# Altium schematic sheet Drive.SchDoc
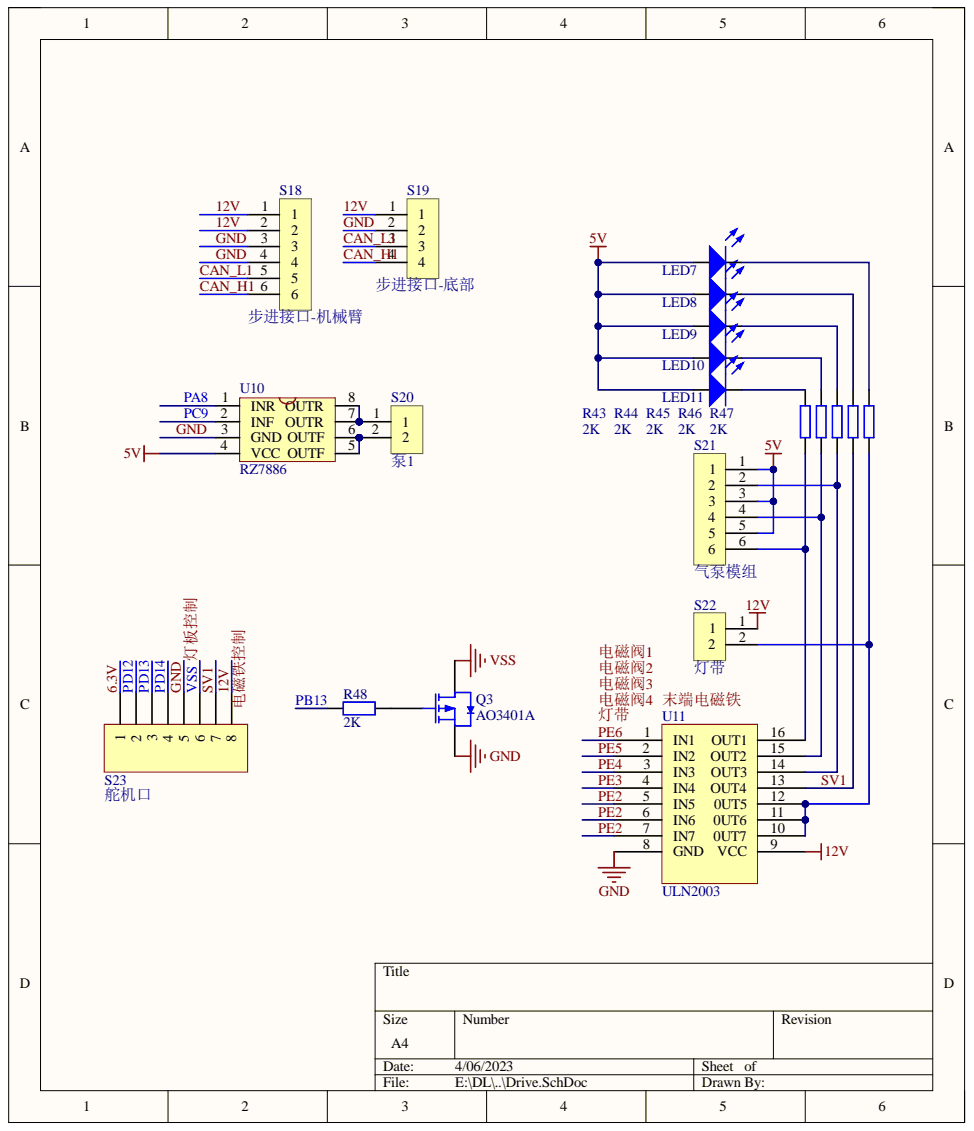
[diagram p0: Drive.SchDoc]

## Parts
LED7  LED  [LED 0603B]
LED8  LED  [LED 0603B]
LED9  LED  [LED 0603B]
LED10  LED  [LED 0603B]
LED11  LED  [LED 0603B]
Q3  AO3401A  [SOT23]
R43  2K  [0402_R]
R44  2K  [0402_R]
R45  2K  [0402_R]
R46  2K  [0402_R]
R47  2K  [0402_R]
R48  2K  [0402_R]
S18  步进接口-机械臂  [卧式PH2.0mm-6P]
S19  步进接口-底部  [卧式PH2.0mm-4P]
S20  泵1  [卧式PH2.0mm-2P]
S21  气泵模组  [卧式PH2.0mm-6P]
S22  灯带  [卧式PH2.0mm-2P]
S23  舵机口  [卧式PH2.0mm-8P]
U10  RZ7886  [SOP8_M]
U11  ULN2003  [SOP16_M]

## Nets
5V: LED7.1 LED8.1 LED9.1 LED10.1 LED11.1 S21.1 S21.3 S21.5 U10.4(VCC)
6.3V: S23.1
12V: S18.1 S18.2 S19.1 S22.1 S23.8 U11.9(VCC)
CAN_H1: S18.6 S19.4
CAN_L1: S18.5 S19.3
GND: Q3.2 S18.3 S18.4 S19.2 S23.5 U10.3(GND) U11.8(GND)
PA8: U10.1(INR)
PB13: R48.1
PC9: U10.2(INF)
PD12: S23.2
PD13: S23.3
PD14: S23.4
PE2: U11.5(IN5) U11.6(IN6) U11.7(IN7)
PE3: U11.4(IN4)
PE4: U11.3(IN3)
PE5: U11.2(IN2)
PE6: U11.1(IN1)
SV1: R46.2 S23.7 U11.13(OUT4)
VSS: Q3.3 S23.6
NetLED7_2: LED7.2 R47.1
NetLED8_2: LED8.2 R46.1
NetLED9_2: LED9.2 R45.1
NetLED10_2: LED10.2 R44.1
NetLED11_2: LED11.2 R43.1
NetQ3_1: Q3.1 R48.2
NetR43_2: R43.2 S21.6 U11.16(OUT1)
NetR44_2: R44.2 S21.4 U11.15(OUT2)
NetR45_2: R45.2 S21.2 U11.14(OUT3)
NetR47_2: R47.2 S22.2 U11.10(0UT7) U11.11(0UT6) U11.12(0UT5)
NetS20_1: S20.1 U10.7(OUTR) U10.8(OUTR)
NetS20_2: S20.2 U10.5(OUTF) U10.6(OUTF)
末端电磁铁:
灯带:
电磁铁控制:
电磁阀1:
电磁阀2:
电磁阀3:
电磁阀4:

## Library data of the parts
Q3 = AO3401A P道沟 X13A15 SOT-23: MOS(场效应管); Component Kind: Standard; ComponentLink1Description: 供应商链接; ComponentLink1URL: http://www.szlcsc.com/product/details_15801.html; Library Name: JLC.IntLib; Package: SOT-23; Supplier: LC; SuppliersPartNumber: C15127
R43-R47 = RES2: Component Kind: Standard; Library Name: 启航号原理图库.lib
R48 = 0603 1.2KΩ (1201) 1%: 贴片电阻; Component Kind: Standard; ComponentLink1Description: 供应商链接; ComponentLink1URL: http://www.szlcsc.com/product/details_23492.html; Library Name: JLC.IntLib; Package: 0603; Supplier: LC; SuppliersPartNumber: C22765
S18 S21 = S-6: Component Kind: Standard; Library Name: ZBC123Schlib1.SchLib
S19 = S-4: Component Kind: Standard; Library Name: ZBC123Schlib1.SchLib
S20 S22 = S-2: Component Kind: Standard; Library Name: ZBC123Schlib1.SchLib
S23 = MHDR1X8: Header, 8-Pin; Component Kind: Standard; LatestRevisionDate: 17-Jul-2002; LatestRevisionNote: Re-released for DXP Platform.; Library Name: Miscellaneous Connectors.IntLib; Signal Integrity: Connector; Publisher: Altium Limited
U10 = RZ7889: Component Kind: Standard; Library Name: 103驱动2.SCHLIB
LED7-LED11 = LED: Component Kind: Standard; Library Name: 103驱动2.SCHLIB
U11 = ULN2003: Component Kind: Standard; Library Name: ZBC124Schlib1.SchLib
